# Altium schematic sheet Power.SchDoc
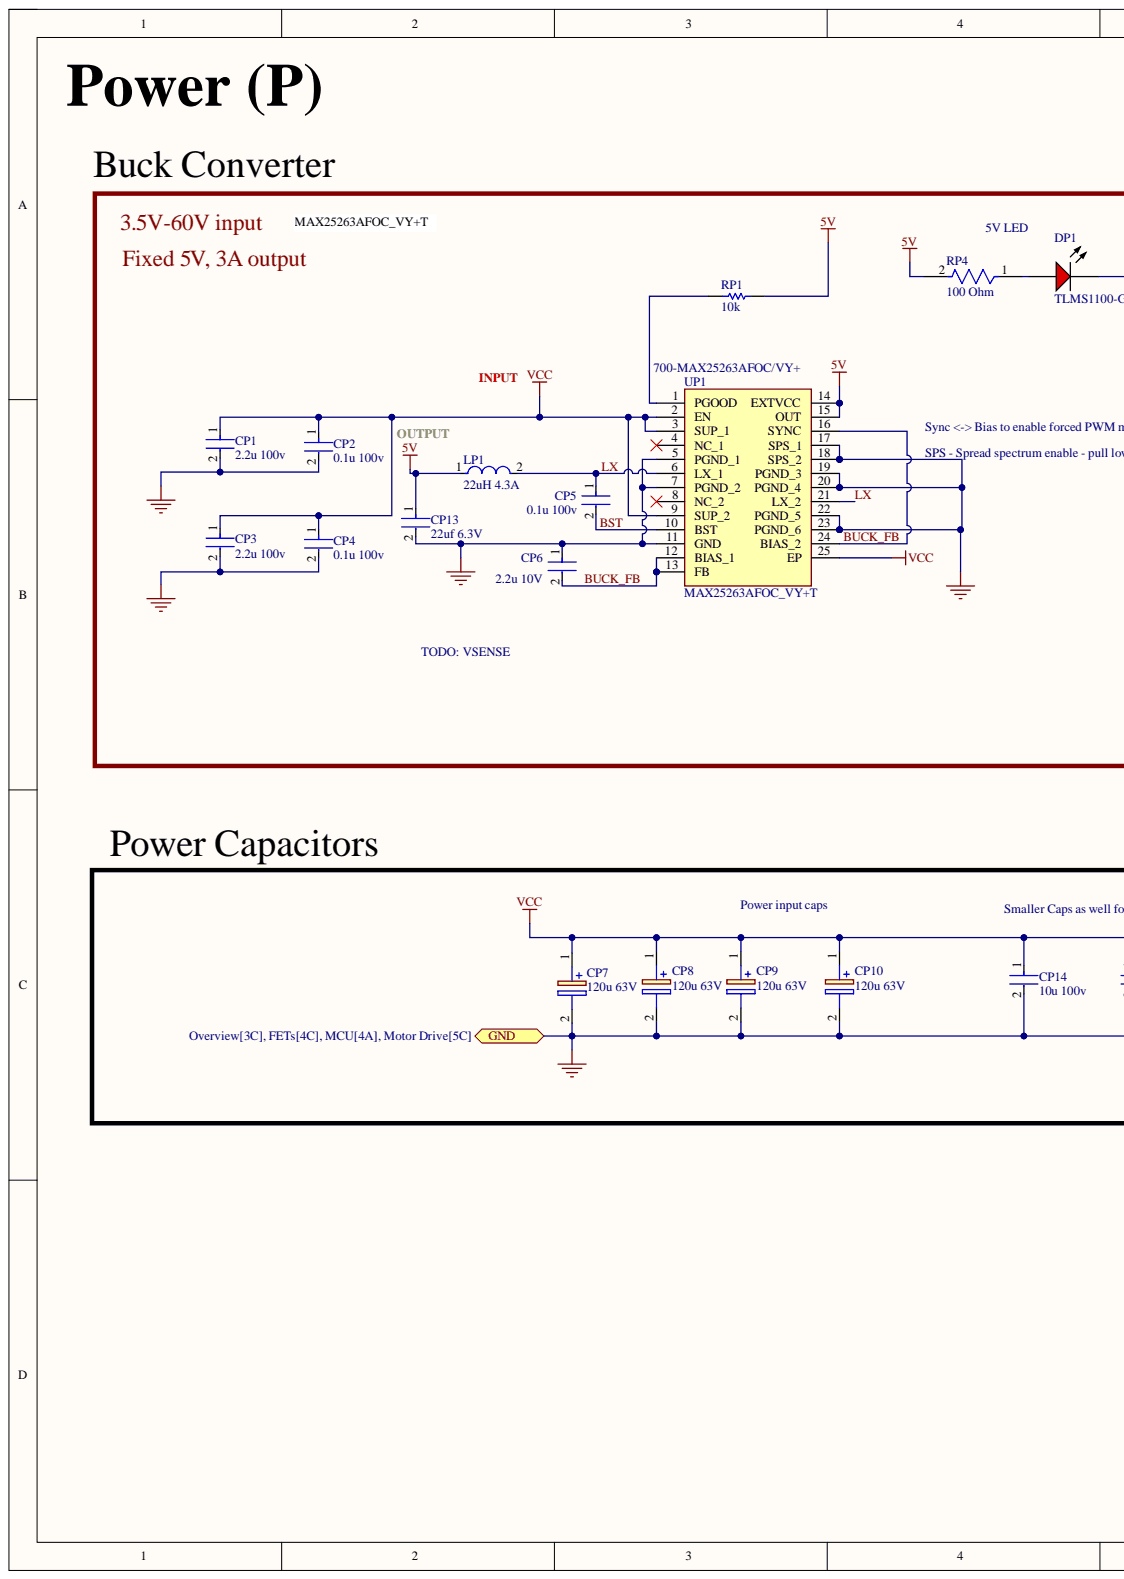
[diagram p0: Power.SchDoc, left]
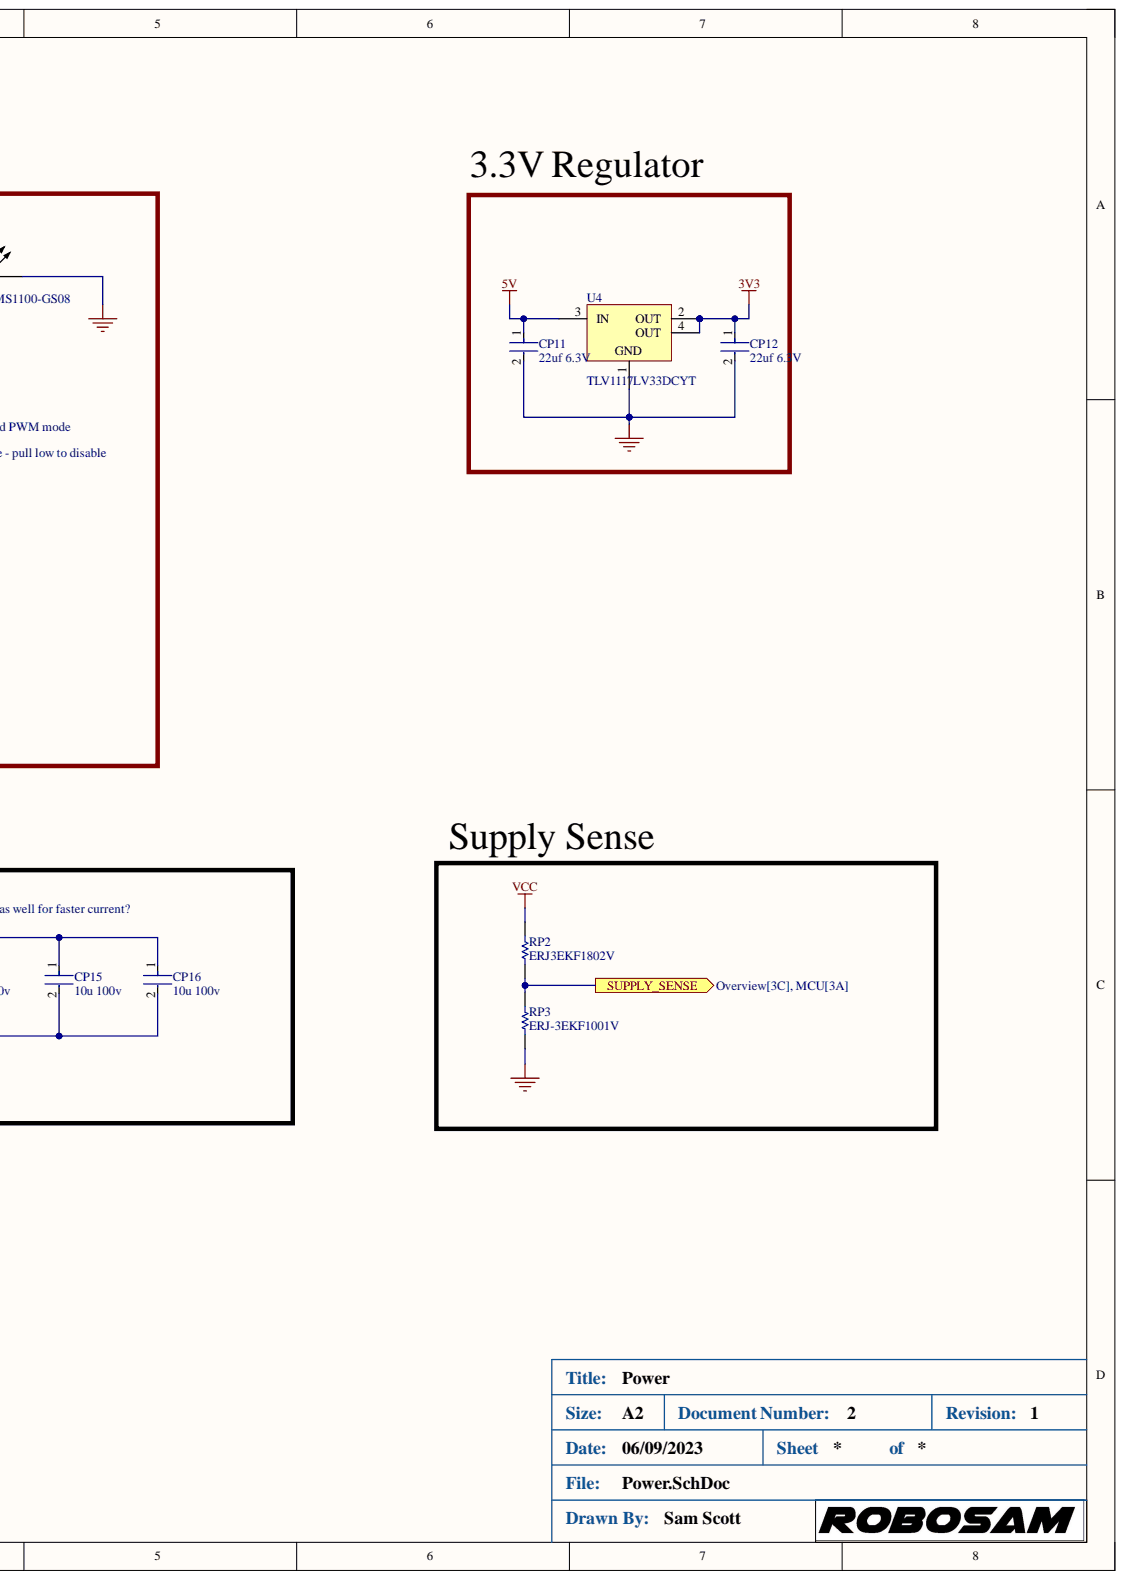
[diagram p1: Power.SchDoc, right]

## Parts
CP1  2.2u 100v  [FP-1210-L_3_3_0_4-W_2_5_0-IPC_B]
CP2  0.1u 100v  [FP-CL21-IPC_A]
CP3  2.2u 100v  [FP-1210-L_3_3_0_4-W_2_5_0-IPC_B]
CP4  0.1u 100v  [FP-CL21-IPC_A]
CP5  0.1u 100v  [FP-CL21-IPC_A]
CP6  2.2u 10V  Voltage: 10V  [FP-GRM188-0_1-e0_2_0_5-MFG]
CP7  120u 63V  [CAPAE1030X1280N]
CP8  120u 63V  [CAPAE1030X1280N]
CP9  120u 63V  [CAPAE1030X1280N]
CP10  120u 63V  [CAPAE1030X1280N]
CP11  22uf 6.3V  [FP-GRM21B-0_15-MFG]
CP12  22uf 6.3V  Voltage: 6.3V  [FP-GRM21B-0_15-MFG]
CP13  22uf 6.3V  Voltage: 6.3V  [FP-GRM21B-0_15-MFG]
CP14  10u 100v  [FP-GRM32E-0_3-0_2-MFG]
CP15  10u 100v  [FP-GRM32E-0_3-0_2-MFG]
CP16  10u 100v  [FP-GRM32E-0_3-0_2-MFG]
DP1  TLMS1100-GS08  [VISH-TLMX1100_V]
LP1  22uH 4.3A  Current Rating: 4.3A  Inductance: 22uH  [FP-IHLP-3232DZ-FP_2_413x5-MFG]
RP1  10k  [RESC1609X50X30NL8T20]
RP2  ERJ3EKF1802V  [RESC1608X55X30LL15T15]
RP3  ERJ-3EKF1001V  [RESC1608X55X30LL15T15]
RP4  100 Ohm  [FP-RC0603-0_55-MFG]
U4  TLV1117LV33DCYT  [DCY0004A_M]
UP1  MAX25263AFOC_VY+T  Supplier Part Number 1: 700-MAX25263AFOC/VY+  [MAX25263AFOCVYT]

## Ports
GND, SUPPLY_SENSE

## Nets
3V3: CP12.1 U4.2(OUT) U4.4(OUT)
5V: CP11.1 CP13.1 LP1.1 RP1.1 RP4.2 U4.3(IN) UP1.14(EXTVCC) UP1.15(OUT)
VCC: CP1.1 CP2.1 CP3.1 CP4.1 CP7.1 CP8.1 CP9.1 CP10.1 CP14.1 CP15.1 CP16.1 RP2.2 UP1.2(EN) UP1.3(SUP_1) UP1.9(SUP_2) UP1.25(EP)
BST: CP5.2 UP1.10(BST)
BUCK_FB: CP6.2 UP1.12(BIAS_1) UP1.13(FB) UP1.16(SYNC) UP1.24(BIAS_2)
GND: CP1.2 CP2.2 CP3.2 CP4.2 CP6.1 CP7.2 CP8.2 CP9.2 CP10.2 CP11.2 CP12.2 CP13.2 CP14.2 CP15.2 CP16.2 DP1.2 RP3.1 U4.1 UP1.5(PGND_1) UP1.7(PGND_2) UP1.11(GND) UP1.17(SPS_1) UP1.18(SPS_2) UP1.19(PGND_3) UP1.20(PGND_4) UP1.22(PGND_5) UP1.23(PGND_6) ; port GND
LX: CP5.1 LP1.2 UP1.6(LX_1) UP1.21(LX_2)
SUPPLY_SENSE: RP2.1 RP3.2 ; port SUPPLY_SENSE
NetDP1_1: DP1.1 RP4.1
NetRP1_2: RP1.2 UP1.1(PGOOD)

Not connected: UP1 4(NC_1) 8(NC_2)

## Library data of the parts
CP1 CP3 = CMP-1038-02104-3: General Purpose Ceramic Capacitor, 1210, 2.2uF, 10%, X7R, 15%, 100V; Dissipation Factor: 2.5%; Capacitance: 2.2uF; Case Code (Imperial): 1210; Case Code (Metric): 3225; Case/Package: 1210; Component Kind: Standard; Construction: Flat; Contact Plating: Tin; Depth: 2.5mm; Dielectric: X7R; Height: 2.79mm; Lead Free: Lead Free; Length: 3.2mm; Library Name: Altium Content Vault; Max Operating Temperature: 125°C; Min Operating Temperature: -55°C; Mount: Surface Mount; Number of Pins: 2; Package Quantity: 1; Packaging: Tape and Reel; Radiation Hardening: No; REACH SVHC: No SVHC; RoHS Compliant: Yes; Series: -; Termination: SMD/SMT; Thickness: 0.11inch; Tolerance: 10%; Voltage: 100V; Voltage Rating: 100V; Voltage Rating (DC): 100V; Width: 2.5mm
CP2 CP4 CP5 = CMP-13271-000177-1: CL21 Series 0805 100nF 100V ±10% Tolerance X7R Multilayer Ceramic Chip Capacitor; Capacitance: 100nF; Case Code (Imperial): 0805; Case Code (Metric): 2012; Case/Package: 0805; Component Kind: Standard; Features: Soft Termination; Length: 0.079inch; Library Name: Altium Content Vault; Max Operating Temperature: 125°C; Min Operating Temperature: -55°C; Mount: Surface Mount; Packaging: Tape and Reel; Ratings: AEC-Q200; Thickness: 0.053inch; Tolerance: 10%; Voltage Rating: 100V; Width: 0.049inch
CP6 = CMP-2006-02836-2: Chip Multilayer Ceramic Capacitors for General Purpose, 0603, 2.2uF, X7R, 15%, 10%, 10V; Capacitance: 2.2uF; Case Code (Imperial): 0603; Case Code (Metric): 1608; Case/Package: 0603; Component Kind: Standard; Depth: 0.8mm; Dielectric: X7R; Height: 0.8mm; Lead Free: Lead Free; Length: 1.6mm; Library Name: Altium Content Vault; Material: Ceramic; Max Operating Temperature: 125°C; Min Operating Temperature: -55°C; Mount: Surface Mount; Number of Pins: 2; Package Quantity: 1; Packaging: Cut Tape; REACH SVHC: No SVHC; Termination: SMD/SMT; Thickness: 0.035inch; Tolerance: 10%; Voltage Rating: 10V; Voltage Rating (DC): 10V; Weight: 7.1E-05oz; Width: 0.031inch
CP7-CP10 = B40940A8127M000: Capacitor Polarised; Manufacturer_Name: TDK; Component Kind: Standard; Datasheet Link: https://product.tdk.com/system/files/dam/doc/product/capacitor/aluminum-electrolytic/hybrid-polymer/data_sheet/20/30/db/aec/b40940.pdf; Height: 12.8mm; Manufacturer_Part_Number: B40940A8127M000; Mouser Part Number: 871-B40940A8127M000; Mouser Price/Stock: https://www.mouser.co.uk/ProductDetail/EPCOS-TDK/B40940A8127M000?qs=zW32dvEIR3vkKPlWSJE%2Frw%3D%3D
CP11-CP13 = CMP-2007-03385-2: Chip Multilayer Ceramic Capacitors for General Purpose, 0805, 22uF, X5R, 15%, 20%, 6.3V; Capacitance: 22uF; Case Code (Imperial): 0805; Case Code (Metric): 2012; Case/Package: 0805; Component Kind: Standard; Depth: 1.25mm; Dielectric: X5R; Height: 1.25mm; Lead Free: Lead Free; Length: 2mm; Library Name: Altium Content Vault; Material: Ceramic; Max Operating Temperature: 85°C; Min Operating Temperature: -55°C; Mount: Surface Mount; Package Quantity: 1; Packaging: Tape and Reel; Radiation Hardening: No; REACH SVHC: No SVHC; Termination: SMD/SMT; Thickness: 0.053inch; Tolerance: 20%; Voltage Rating: 6.3V; Voltage Rating (DC): 6.3V; Width: 0.049inch
  CP11: Voltage: 6.3V
CP14-CP16 = CMP-06035-000081-2: Chip Multilayer Ceramic Capacitors for General Purpose, 1210, 10uF, X7S, 22%, 10%, 100V; Capacitance: 10uF; Case Code (Imperial): 1210; Case Code (Metric): 3225; Case/Package: 1210; Component Kind: Standard; Library Name: Altium Content Vault; Max Operating Temperature: 125°C; Min Operating Temperature: -55°C; Mount: Surface Mount; Packaging: Tape and Reel; Tolerance: 10%; Voltage Rating: 100V
DP1 = CMP-1666-00027-1: LED, RED, 2.1 V, -40 to 100 degC, 2-Pin SMD (0603), RoHS, Tape and Reel; Capacitance: 12 pF; Resistance: 100000000000 Ohm; Tolerance: 5%; Type (Capacitors): C0G; Voltage Rating: 50 V; Case Code (Imperial): 0603; Case Code (Metric): 1608; Case/Package: 0603; Component Kind: Standard; ComponentLink1Description: Manufacturer URL; ComponentLink1URL: http://www.vishay.com/; ComponentLink2Description: Datasheet; ComponentLink2URL: http://www.vishay.com/docs/83173/tlmb1100.pdf; DatasheetVersion: Rev. 2.7, 03/2016; Height: 0.8 mm; Length: 1.6 mm; Library Name: Altium Content Vault; Max Operating Temperature: 125degC; Min Operating Temperature: -55degC; Mount: Surface Mount; Package Quantity: 4000; PackageDescription: 2-Pin Surface Mount Device, Body 1.6 x 0.8 mm; PackageReference: TLMX1100; Packaging: Tape and Reel; Pins: 2; Radiation Hardening: No; REACH SVHC: No SVHC; RoHSCompliant: True; Voltage Rating (DC): 50 V; Width: 0.8 mm
LP1 = CMP-02424-000164-1: Commercial Inductors, Low DCR Series 22uH 4.3A 94.2mΩ 20%; Core Material: Metal; DC Resistance (DCR): 94.2mR; Max DC Current: 3.3A; Self Resonant Frequency: 8.2MHz; Shielding: Shielded; Test Frequency: 100kHz; Case/Package: 3232; Component Kind: Standard; Depth: 8.26mm; Height: 4mm; Height - Seated (Max): 0.157inch; Inductance Tolerance: 20%; Lead Free: Lead Free; Length: 8.64mm; Library Name: Altium Content Vault; Max Operating Temperature: 125°C; Military Standard: Not; Min Operating Temperature: -55°C; Mount: Surface Mount; Number of Pins: 2; Package Quantity: 1; Packaging: Tape and Reel; Radiation Hardening: No; RMS Current (Irms): 4.3A; Saturation Current: 3.3A; Termination: SMD/SMT; Tolerance: 20%; Width: 0.322inch
RP1 = CMP-1666-00005-4: Chip Resistor, 10 KOhm, +/- 1%, 100 mW, -55 to 155 degC, 0603 (1608 Metric), RoHS, Tape and Reel; Manufacturer: Vishay Semiconductor; Voltage Rating: 75 V; Component Kind: Standard; ComponentLink1Description: Manufacturer URL; ComponentLink1URL: http://www.vishay.com/; ComponentLink2Description: Datasheet; ComponentLink2URL: https://datasheet.ciiva.com/11809/0900766b80d98afc-11809037.pdf; DatasheetVersion: 06/2012; Height: 0.45 mm; Length: 1.55 mm; Library Name: Altium Content Vault; Max Operating Temperature: 155 degC; Min Operating Temperature: -55 degC; Mounting Technology: Surface Mount; Package Quantity: 5000; PackageDescription: 2-Pin Surface Mount Device, Body 1.575 x 0.85 mm; PackageReference: 0603; Packaging: Tape and Reel; REACH SVHC: Unknown; RoHSCompliant: true
RP2 = CMP-1012-00650-3: Fixed Resistor, Metal Glaze/thick Film, 0.1W, 18000ohm, 75V, 1% +/-Tol, 100ppm/Cel, Surface Mount, 0603; Manufacturer: Panasonic; Component Kind: Standard; Library Name: Altium Content Vault; Part Number: ERJ3EKF1802V
RP3 = CMP-2000-00180-1: Manufacturer: Panasonic; Component Kind: Standard; Library Name: Altium Content Vault; Part Number: ERJ-3EKF1001V
RP4 = CMP-2100-03663-2: NexarPartId: 55402879; Power Rating: 100mW; Resistance: 100Ω; Temperature Coefficient: 100ppm/°C; Tolerance: 1%; Voltage Rating: 50V; Case Code (Imperial): 0603; Case Code (Metric): 0603; Case/Package: 0603; Component Kind: Standard; Composition: Thick Film; Depth: 800µm; Height: 450µm; Lead Free: Lead Free; Length: 1.6mm; Library Name: Altium Content Vault; Max Operating Temperature: 155°C; Military Standard: Not; Min Operating Temperature: -55°C; Number of Pins: 2; Packaging: Tape & Reel; REACH SVHC: Unknown; RoHS: Compliant; Schedule B: 8533210045, 8533210045; Termination: SMD/SMT; Voltage Rating (DC): 50V; Width: 800µm
U4 = CMP-0395-00499-2: Single Output LDO, 1 A, Fixed 3.3 V Output, 2 to 5.5 V Input, 4-pin SOT-223 (DCY), -40 to 125 degC, Green (RoHS & no Sb/Br); Manufacturer: Texas Instruments; Accuracy(%): 2; Fixed Output Options(V): 3.3; Iout(Max)(A): 1; Iq(Typ)(mA): 0.05; SubFamily: Single Channel LDO; Vdo(Typ)(mV): 1200; Vin(Max)(V): 5.5; Code_JEDEC: TO-261; Component Kind: Standard; ComponentLink1Description: Manufacturer URL; ComponentLink1URL: http://www.ti.com/; ComponentLink2Description: Datasheet; ComponentLink2URL: http://www.ti.com/general/docs/lit/getliterature.tsp?genericPartNumber=TLV1117LV33&fileType=pdf; ComponentLink3Description: Package Specification; ComponentLink3URL: http://www.ti.com/litv/pdf/mpds094a; DatasheetVersion: SBVS160A; Eco-Plan: Green (RoHS & no Sb/Br); Library Name: Altium Content Vault; Mounting Technology: Surface Mount; PackageDescription: 4-Pin Plastic Small Outline, Body 3.5 x 6.5 mm, Pitch 2.3 mm; PackageReference: DCY0004A; PackageVersion: 4202506/B; PartNumber: TLV1117LV33DCYT; Vin(Min)(V): 2; Vout Adj(Max)(V): 3.3; Vout Adj(Min)(V): 3.3
UP1 = MAX25263AFOC_VY+T: Integrated Circuit; Manufacturer_Name: Analog Devices; Manufacturer_Part_Number: MAX25263AFOC/VY+T; Component Kind: Standard; Datasheet Link: https://datasheets.maximintegrated.com/en/ds/MAX25262-MAX25263.pdf; Height: 0.8mm; Supplier 1: Mouser
